# Altium schematic sheet S7CAS-PLEIADES-CARTE-MERE_P01_ALIM.SchDoc
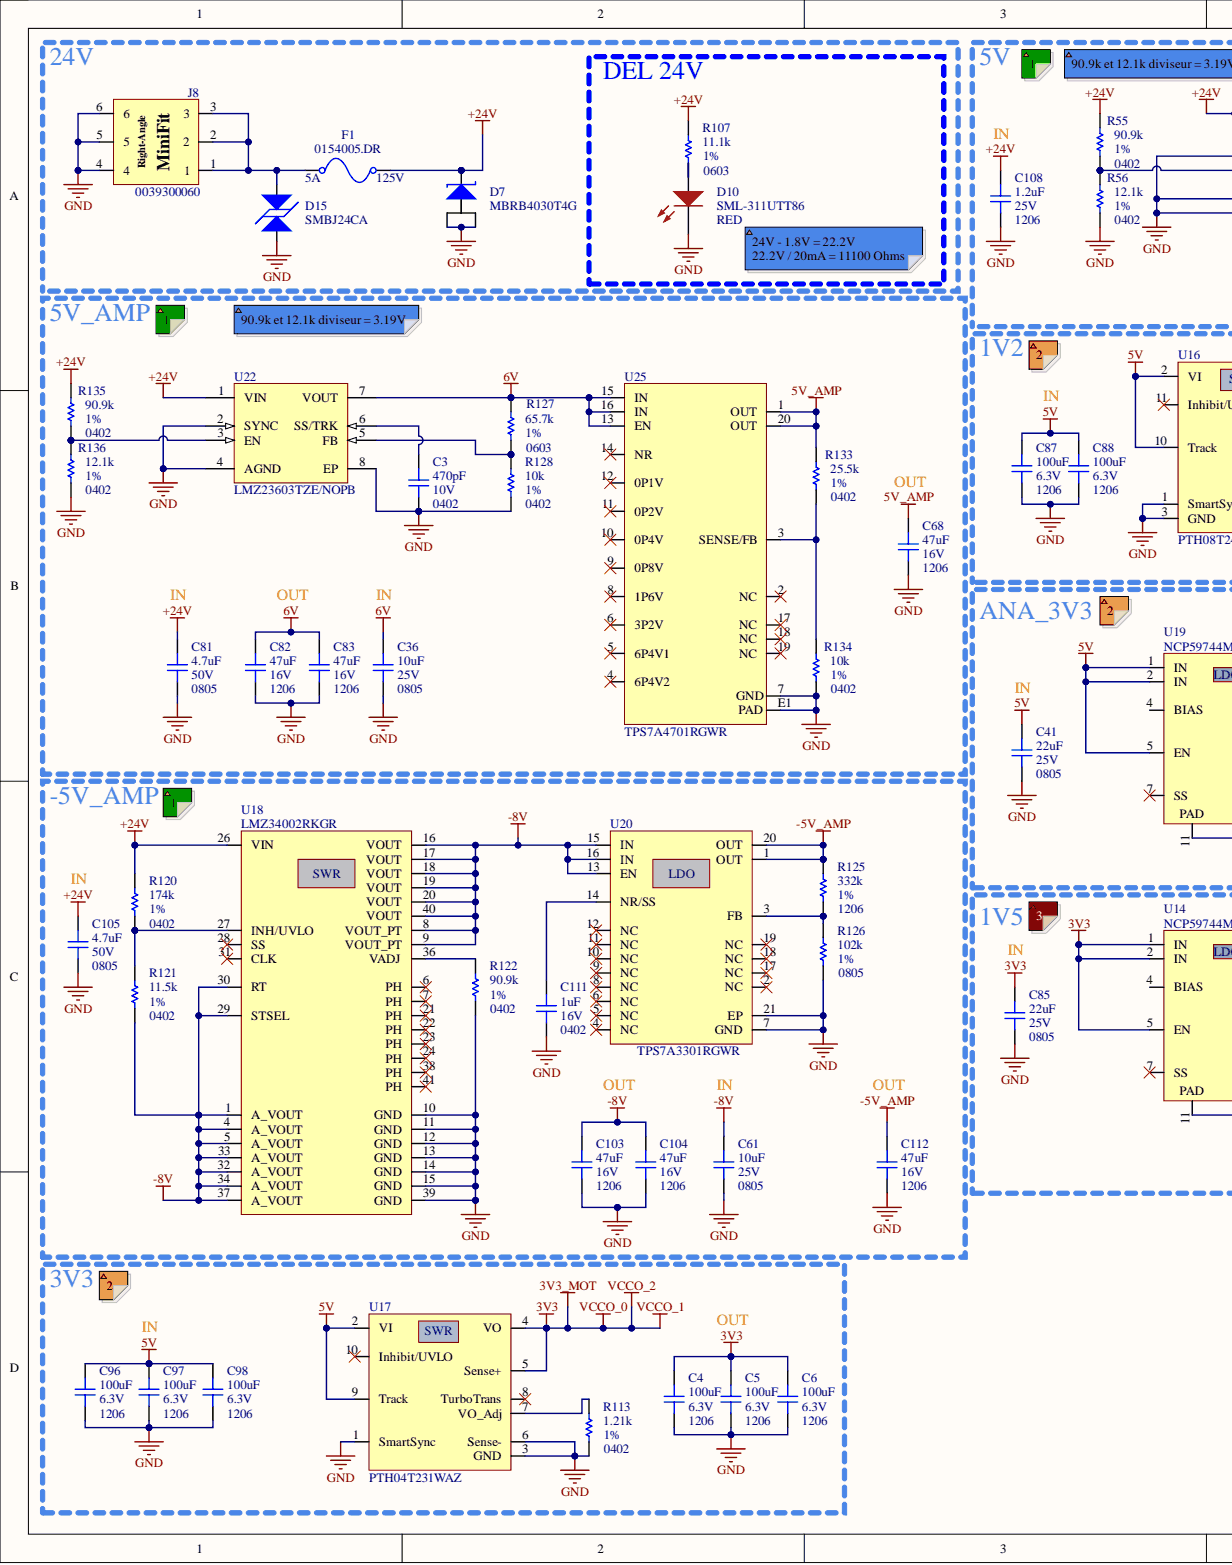
[diagram p0: S7CAS-PLEIADES-CARTE-MERE_P01_ALIM.SchDoc, left]
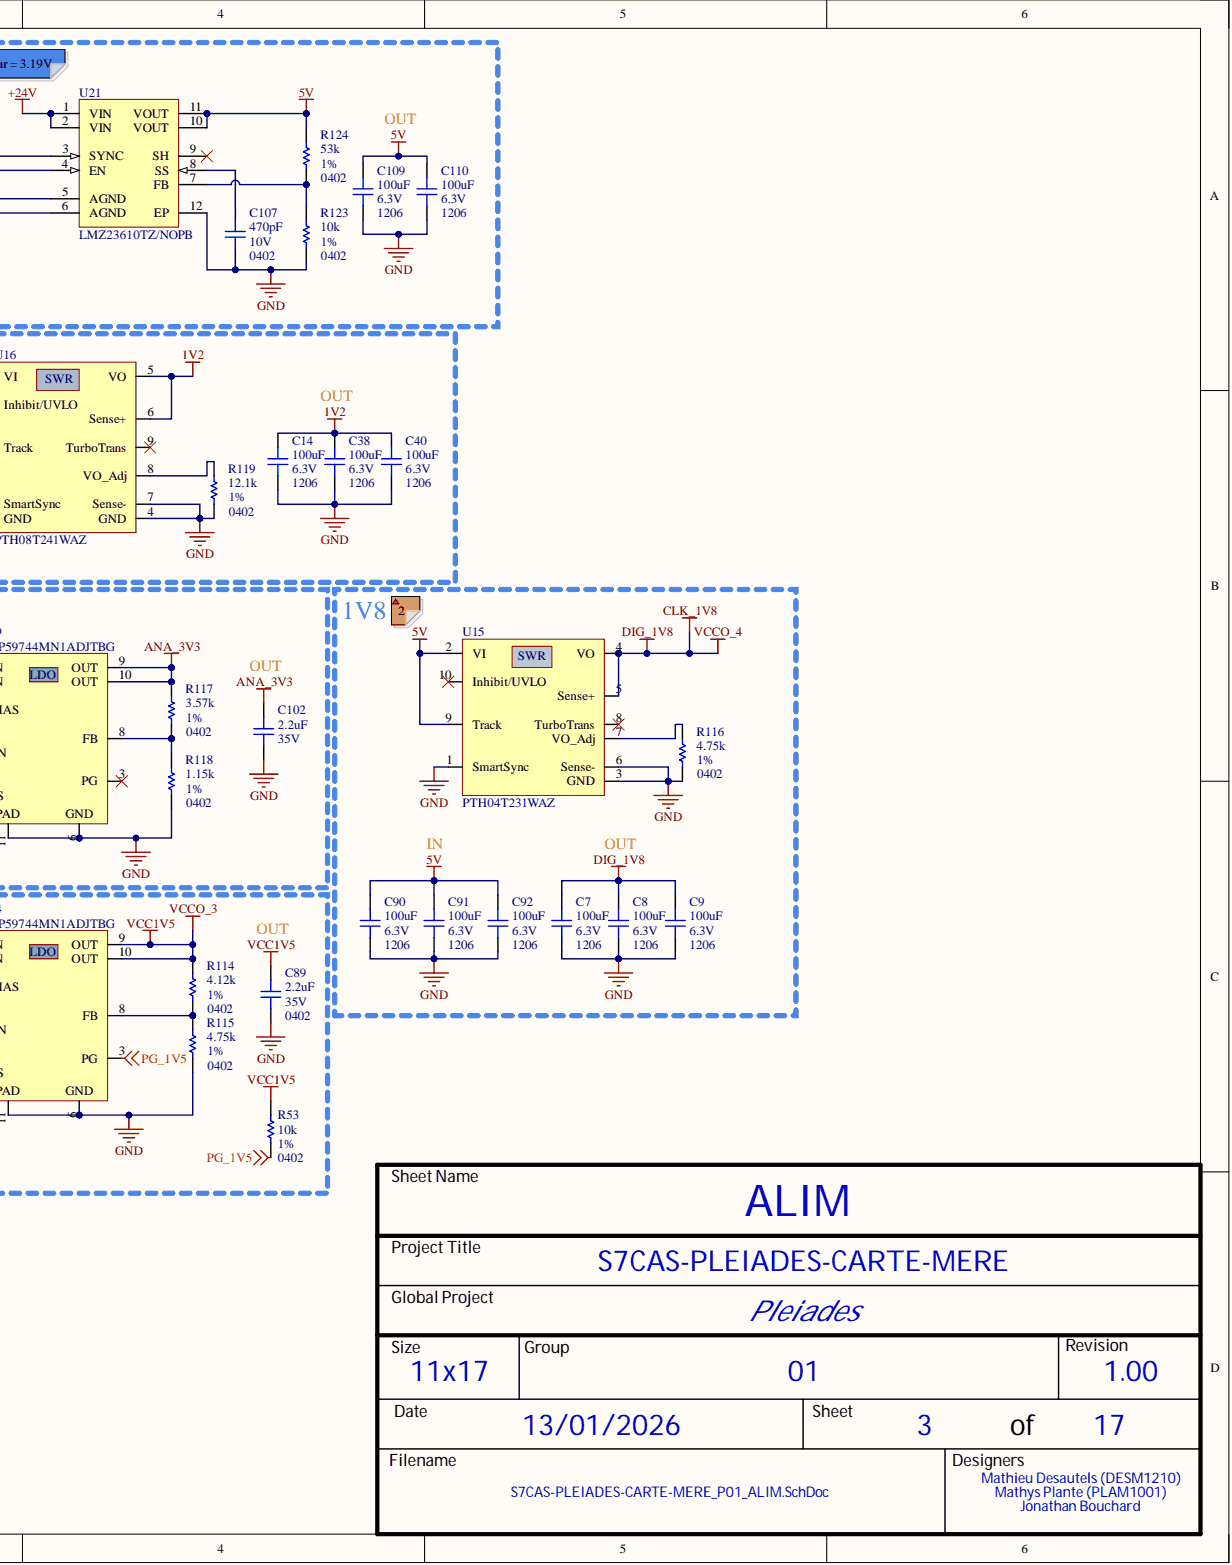
[diagram p1: S7CAS-PLEIADES-CARTE-MERE_P01_ALIM.SchDoc, right]

## Parts
C3  value 470pF  Package: 0402  Voltage: 10V  [CAP_0402]  (hidden comment: CAP - 0402)
C4  value 100uF  Package: 1206  Voltage: 6.3V  [CAP_1206]  (hidden comment: CAP - 1206)
C5  value 100uF  Package: 1206  Voltage: 6.3V  [CAP_1206]  (hidden comment: CAP - 1206)
C6  value 100uF  Package: 1206  Voltage: 6.3V  [CAP_1206]  (hidden comment: CAP - 1206)
C7  value 100uF  Package: 1206  Voltage: 6.3V  [CAP_1206]  (hidden comment: CAP - 1206)
C8  value 100uF  Package: 1206  Voltage: 6.3V  [CAP_1206]  (hidden comment: CAP - 1206)
C9  value 100uF  Package: 1206  Voltage: 6.3V  [CAP_1206]  (hidden comment: CAP - 1206)
C14  value 100uF  Package: 1206  Voltage: 6.3V  [CAP_1206]  (hidden comment: CAP - 1206)
C36  value 10uF  Package: 0805  Voltage: 25V  [CAP_0805]  (hidden comment: CAP - 0805)
C38  value 100uF  Package: 1206  Voltage: 6.3V  [CAP_1206]  (hidden comment: CAP - 1206)
C40  value 100uF  Package: 1206  Voltage: 6.3V  [CAP_1206]  (hidden comment: CAP - 1206)
C41  value 22uF  Package: 0805  Voltage: 25V  [CAP_0805]  (hidden comment: CAP - 0805)
C61  value 10uF  Package: 0805  Voltage: 25V  [CAP_0805]  (hidden comment: CAP - 0805)
C68  value 47uF  Package: 1206  Voltage: 16V  [CAP_1206]  (hidden comment: CAP - 1206)
C81  value 4.7uF  Package: 0805  Voltage: 50V  [CAP_0805]  (hidden comment: CAP - 0805)
C82  value 47uF  Package: 1206  Voltage: 16V  [CAP_1206]  (hidden comment: CAP - 1206)
C83  value 47uF  Package: 1206  Voltage: 16V  [CAP_1206]  (hidden comment: CAP - 1206)
C85  value 22uF  Package: 0805  Voltage: 25V  [CAP_0805]  (hidden comment: CAP - 0805)
C87  value 100uF  Package: 1206  Voltage: 6.3V  [CAP_1206]  (hidden comment: CAP - 1206)
C88  value 100uF  Package: 1206  Voltage: 6.3V  [CAP_1206]  (hidden comment: CAP - 1206)
C89  value 2.2uF  Package: 0402  Voltage: 35V  [CAP_0402]  (hidden comment: CAP - 0402)
C90  value 100uF  Package: 1206  Voltage: 6.3V  [CAP_1206]  (hidden comment: CAP - 1206)
C91  value 100uF  Package: 1206  Voltage: 6.3V  [CAP_1206]  (hidden comment: CAP - 1206)
C92  value 100uF  Package: 1206  Voltage: 6.3V  [CAP_1206]  (hidden comment: CAP - 1206)
C96  value 100uF  Package: 1206  Voltage: 6.3V  [CAP_1206]  (hidden comment: CAP - 1206)
C97  value 100uF  Package: 1206  Voltage: 6.3V  [CAP_1206]  (hidden comment: CAP - 1206)
C98  value 100uF  Package: 1206  Voltage: 6.3V  [CAP_1206]  (hidden comment: CAP - 1206)
C102  value 2.2uF  Voltage: 35V  [CAP_0402]  (hidden comment: CAP - 0402)
C103  value 47uF  Package: 1206  Voltage: 16V  [CAP_1206]  (hidden comment: CAP - 1206)
C104  value 47uF  Package: 1206  Voltage: 16V  [CAP_1206]  (hidden comment: CAP - 1206)
C105  value 4.7uF  Package: 0805  Voltage: 50V  [CAP_0805]  (hidden comment: CAP - 0805)
C107  value 470pF  Package: 0402  Voltage: 10V  [CAP_0402]  (hidden comment: CAP - 0402)
C108  value 1.2uF  Package: 1206  Voltage: 25V  [CAP_1206]  (hidden comment: CAP - 1206)
C109  value 100uF  Package: 1206  Voltage: 6.3V  [CAP_1206]  (hidden comment: CAP - 1206)
C110  value 100uF  Package: 1206  Voltage: 6.3V  [CAP_1206]  (hidden comment: CAP - 1206)
C111  value 1uF  Package: 0402  Voltage: 16V  [CAP_0402]  (hidden comment: CAP - 0402)
C112  value 47uF  Package: 1206  Voltage: 16V  [CAP_1206]  (hidden comment: CAP - 1206)
D7  MBRB4030T4G  [DIO_SCHOTTKY_MBRB4030T4G]
D10  SML-311UTT86  value RED  Package: 0603  [LED_0603]
D15  SMBJ24CA  [TVS_PWR_SMBJ36CA]
F1  0154005.DR  Current Rating: 5A  Voltage Rating: 125V  [FUS_0154XXX.DR]
J8  0039300060  [CON_MINIFIT_0039300060]
R53  value 10k  Package: 0402  Precision: 1%  [RES_0402]  (hidden comment: RES - 0402)
R55  value 90.9k  Precision: 1%  [RES_0402]  (hidden comment: RES - 0402)
R56  value 12.1k  Package: 0402  Precision: 1%  [RES_0402]  (hidden comment: RES - 0402)
R107  value 11.1k  [RES_0603]  (hidden comment: RES - 0603)
R113  value 1.21k  Package: 0402  Precision: 1%  [RES_0402]  (hidden comment: RES - 0402)
R114  value 4.12k  Precision: 1%  [RES_0402]  (hidden comment: RES - 0402)
R115  value 4.75k  Package: 0402  Precision: 1%  [RES_0402]  (hidden comment: RES - 0402)
R116  value 4.75k  Package: 0402  Precision: 1%  [RES_0402]  (hidden comment: RES - 0402)
R117  value 3.57k  Package: 0402  Precision: 1%  [RES_0402]  (hidden comment: RES - 0402)
R118  value 1.15k  Package: 0402  Precision: 1%  [RES_0402]  (hidden comment: RES - 0402)
R119  value 12.1k  Package: 0402  Precision: 1%  [RES_0402]  (hidden comment: RES - 0402)
R120  value 174k  Package: 0402  Precision: 1%  [RES_0402]  (hidden comment: RES - 0402)
R121  value 11.5k  Package: 0402  Precision: 1%  [RES_0402]  (hidden comment: RES - 0402)
R122  value 90.9k  Package: 0402  Precision: 1%  [RES_0402]  (hidden comment: RES - 0402)
R123  value 10k  Package: 0402  Precision: 1%  [RES_0402]  (hidden comment: RES - 0402)
R124  value 53k  Package: 0402  Precision: 1%  [RES_0402]  (hidden comment: RES - 0402)
R125  value 332k  Package: 1206  Precision: 1%  [RES_1206]  (hidden comment: RES - 1206)
R126  value 102k  Package: 0805  Precision: 1%  [RES_0805]  (hidden comment: RES - 0805)
R127  value 65.7k  Precision: 1%  [RES_0603]  (hidden comment: RES - 0603)
R128  value 10k  Package: 0402  Precision: 1%  [RES_0402]  (hidden comment: RES - 0402)
R133  value 25.5k  Package: 0402  Precision: 1%  [RES_0402]  (hidden comment: RES - 0402)
R134  value 10k  Package: 0402  Precision: 1%  [RES_0402]  (hidden comment: RES - 0402)
R135  value 90.9k  Precision: 1%  [RES_0402]  (hidden comment: RES - 0402)
R136  value 12.1k  Package: 0402  Precision: 1%  [RES_0402]  (hidden comment: RES - 0402)
U14  NCP59744MN1ADJTBG  [REG_LDO_NCP59744MN1ADJTBG]
U15  PTH04T231WAZ  [REG_SWR_PTH04T231WAZ]
U16  PTH08T241WAZ  [REG_SWR_PTH08T241WAZ]
U17  PTH04T231WAZ  [REG_SWR_PTH04T231WAZ]
U18  LMZ34002RKGR  [REG_SWR_LMZ34002RKGR]
U19  NCP59744MN1ADJTBG  [REG_LDO_NCP59744MN1ADJTBG]
U20  TPS7A3301RGWR  [REG_LDO_TPS7A3301RGWR]
U21  LMZ23610TZ/NOPB  [NDY0011A_M]
U22  LMZ23603TZE/NOPB  [NDW0007A_V]
U25  TPS7A4701RGWR  [REG_TPS7A4701RGWR]

## Nets
1V2: C14.2 C38.2 C40.2 U16.5(VO) U16.6(Sense+)
3V3: C4.2 C5.2 C6.2 C85.2 U14.1(IN) U14.2(IN) U14.5(EN) U17.4(VO) U17.5(Sense+)
5V: C41.2 C87.2 C88.2 C90.2 C91.2 C92.2 C96.2 C97.2 C98.2 C109.2 C110.2 R124.2 U15.2(VI) U15.9(Track) U16.2(VI) U16.10(Track) U17.2(VI) U17.9(Track) U19.1(IN) U19.2(IN) U19.5(EN) U21.10(VOUT) U21.11(VOUT)
5V_AMP: C68.2 R133.2 U25.1(OUT) U25.20(OUT)
6V: C36.2 C82.2 C83.2 R127.2 U22.7(VOUT) U25.13(EN) U25.15(IN) U25.16(IN)
+24V: C81.2 C105.2 C108.2 D7.4 F1.2 R55.2 R107.2 R120.2 R135.2 U18.26(VIN) U21.1(VIN) U21.2(VIN) U22.1(VIN)
-5V_AMP: C112.2 R125.2 U20.1(OUT) U20.20(OUT)
-8V: C61.2 C103.2 C104.2 R121.1 U18.1(A_VOUT) U18.4(A_VOUT) U18.5(A_VOUT) U18.8(VOUT_PT) U18.9(VOUT_PT) U18.16(VOUT) U18.17(VOUT) U18.18(VOUT) U18.19(VOUT) U18.20(VOUT) U18.29(STSEL) U18.30(RT) U18.32(A_VOUT) U18.33(A_VOUT) U18.34(A_VOUT) U18.37(A_VOUT) U18.40(VOUT) U20.13(EN) U20.15(IN) U20.16(IN)
ANA_3V3: C102.2 R117.2 U19.9(OUT) U19.10(OUT)
CLK_1V8: C7.2 C8.2 C9.2 U15.4(VO) U15.5(Sense+)
GND: C3.1 C4.1 C5.1 C6.1 C7.1 C8.1 C9.1 C14.1 C36.1 C38.1 C40.1 C41.1 C61.1 C68.1 C81.1 C82.1 C83.1 C85.1 C87.1 C88.1 C89.1 C90.1 C91.1 C92.1 C96.1 C97.1 C98.1 C102.1 C103.1 C104.1 C105.1 C107.1 C108.1 C109.1 C110.1 C111.1 C112.1 D7.1 D7.3 D10.K D15.2 J8.4 J8.5 J8.6 R56.1 R113.1 R115.1 R116.1 R118.1 R119.1 R122.1 R123.1 R126.1 R128.1 R134.1 R136.1 U14.6 U14.11 U15.1(SmartSync) U15.3(GND) U15.6(Sense-) U16.1(SmartSync) U16.3(GND) U16.4(GND) U16.7(Sense-) U17.1(SmartSync) U17.3(GND) U17.6(Sense-) U18.10(GND) U18.11(GND) U18.12(GND) U18.13(GND) U18.14(GND) U18.15(GND) U18.39(GND) U19.6 U19.11 U20.7(GND) U20.21(EP) U21.3(SYNC) U21.5(AGND) U21.6(AGND) U21.12(EP) U22.2(SYNC) U22.4(AGND) U22.8(EP) U25.7(GND) U25.E1(PAD)
PG_1V5: R53.1 U14.3(PG)
VCC1V5: C89.2 R53.2 R114.2 U14.9(OUT) U14.10(OUT)
NetC3_2: C3.2 U22.6(SS/TRK)
NetC107_2: C107.2 U21.8(SS)
NetC111_2: C111.2 U20.14(NR/SS)
NetD10_A: D10.A R107.1
NetD15_1: D15.1 F1.1 J8.1 J8.2 J8.3
NetR55_1: R55.1 R56.2 U21.4(EN)
NetR113_2: R113.2 U17.7(VO_Adj)
NetR114_1: R114.1 R115.2 U14.8(FB)
NetR116_2: R116.2 U15.7(VO_Adj)
NetR117_1: R117.1 R118.2 U19.8(FB)
NetR119_2: R119.2 U16.8(VO_Adj)
NetR120_1: R120.1 R121.2 U18.27(INH/UVLO)
NetR122_2: R122.2 U18.36(VADJ)
NetR123_2: R123.2 R124.1 U21.7(FB)
NetR125_1: R125.1 R126.2 U20.3(FB)
NetR127_1: R127.1 R128.2 U22.5(FB)
NetR133_1: R133.1 R134.2 U25.3(SENSE/FB)
NetR135_1: R135.1 R136.2 U22.3(EN)

Not connected: U14 4(BIAS) 7(SS); U15 8(TurboTrans) 10(Inhibit/UVLO); U16 9(TurboTrans) 11(Inhibit/UVLO); U17 8(TurboTrans) 10(Inhibit/UVLO); U18 6(PH) 7(PH) 21(PH) 22(PH) 23(PH) 24(PH) 28(SS) 31(CLK) 38(PH) 41(PH); U19 3(PG) 4(BIAS) 7(SS); U20 2(NC) 4(NC) 5(NC) 6(NC) 8(NC) 9(NC) 10(NC) 11(NC) 12(NC) 17(NC) 18(NC) 19(NC); U21 9(SH); U25 2(NC) 4(6P4V2) 5(6P4V1) 6(3P2V) 8(1P6V) 9(0P8V) 10(0P4V) 11(0P2V) 12(0P1V) 14(NR) 17(NC) 18(NC) 19(NC)

## Library data of the parts
C3 C107 = CAP - 0402: Mfg Part Number: C0402C471K8RACAUTO; Precision: 10%; Component Kind: Standard; Description: CAP - 0402; Library Name: s7cas_lib2023.intlib; CreatedBy: Jonathan Bouchard
C4-C9 C14 C38 C40 C87 C88 C90-C92 C96-C98 C109 C110 = CAP - 1206: 100 µF ±20% 6.3V Ceramic Capacitor X6T 1206 (3216 Metric); Mfg Part Number: GRM31CD80J107MEA8K; Mfg: Murata Electronics; Component Kind: Standard; Library Name: s7cas_lib2023.intlib; Precision: 20%; CreatedBy: Jonathan Bouchard
C36 C61 = CAP - 0805: 10 µF ±10% 25V Ceramic Capacitor X5R 0805 (2012 Metric); Mfg Part Number: CL21A106KAYNNNE; Mfg: Samsung Electro-Mechanics; Component Kind: Standard; Library Name: s7cas_lib2023.intlib; Part Number #1: CL21A106KAYNNNE; Precision: 10%; CreatedBy: Jonathan Bouchard
C41 C85 = CAP - 0805: 22 µF ±20% 25V Ceramic Capacitor X5R 0805 (2012 Metric); Mfg Part Number: CL21A226MAYNNNE; Mfg: Samsung Electro-Mechanics; Component Kind: Standard; Library Name: s7cas_lib2023.intlib; Precision: 20%; CreatedBy: Jonathan Bouchard
C68 C82 C83 C103 C104 C112 = CAP - 1206: 47 µF ±20% 16V Ceramic Capacitor X5R 1206 (3216 Metric); Mfg Part Number: EMK316BBJ476ML-T; Mfg: Taiyo Yuden; Component Kind: Standard; Library Name: s7cas_lib2023.intlib; Precision: 20%; CreatedBy: Jonathan Bouchard
C81 C105 = CAP - 0805: 4.7 µF ±10% 50V Ceramic Capacitor X5R 0805 (2012 Metric); Mfg Part Number: GRT21BR61H475KE13L; Mfg: Murata Electronics; Component Kind: Standard; Library Name: s7cas_lib2023.intlib; Precision: 10%; CreatedBy: Jonathan Bouchard
C89 C102 = CAP - 0402: 2.2 µF ±10% 35V Ceramic Capacitor X5R 0402 (1005 Metric); Mfg Part Number: GRM155R6YA225KE11D; Part Number #1: CL05A225KP5NSNC; Mfg: Murata Electronics; Component Kind: Standard; Library Name: s7cas_lib2023.intlib; Precision: 10%; CreatedBy: Jonathan Bouchard
  C102: Package: 0402
C108 = CAP - 1206: 1.2 µF ±10% 25V Ceramic Capacitor X7R 1206 (3216 Metric); Mfg Part Number: C1206C125K3RACTU; Mfg: KEMET; Component Kind: Standard; Library Name: s7cas_lib2023.intlib; Precision: 10%; CreatedBy: Jonathan Bouchard
C111 = CAP - 0402: 1 µF ±10% 16V Ceramic Capacitor X5R 0402 (1005 Metric); Mfg Part Number: CL05A105KO5NNNC; Mfg: Samsung Electro-Mechanics; Component Kind: Standard; Library Name: s7cas_lib2023.intlib; Precision: 10%; CreatedBy: Jonathan Bouchard
D7 = DIO_SCHOTTKY_MBRB4030T4G: DIO - 30V MAX 40 A - TO-263-3; Manufacturer #1: ON Semiconductor; Cryo: UNKNOWN; Current Rating: 40A; GRAMS Lib Spec: 2.8; Magnetic Material: NO; Polarized: Yes; Value: Vf = 550mV @ 40A; Component Kind: Standard; HelpURL: http://www.onsemi.com/pub/Collateral/MBRB4030-D.PDF; Library Name: s7cas_lib2023.intlib; Mfg Part Number: MBRB4030T4G; Package: D2PAK; Part Number #1: MBRB4030T4G; Supplier 1: Digi-Key; Supplier Part Number 1: MBRB4030T4GOSCT-ND; Temperature: -65°C ~ 175°C; Voltage Rating: 30V; CreatedBy/Date: Arnaud Samson - 06/07/18; VerifiedBy: Jonathan Bouchard 2018-07-12
D10 = LED_SML-311UTT86: LED - 620nM RED 2mA - 0603; Mfg: ROHM Semiconductor; Power: 44mW; Voltage: 1.8V; Component Kind: Standard; Current: 2mA; HelpURL: http://media.digikey.com/pdf/Data%20Sheets/Rohm%20PDFs/SML-311%20Series.pdf; Library Name: s7cas_lib2023.intlib; Mfg Part Number: SML-311UTT86; Supplier 1: Digikey; Supplier Part Number 1: 511-1304-1-ND; Temperature: -30°C to 85°C; CreatedBy: Jonathan Bouchard
D15 = TVS_PWR_SMBJ24CA: TVS - 24V 26.7V 600W - DO214AA; Manufacturer #1: Littelfuse Inc.; Cryo: UNKNOWN; Current Rating: 15.5A; GRAMS Lib Spec: 2.8; Polarized: NO; Value: Vbr = 26.7V -  Clamp = 38.9V; Voltage Rating: 24V Standoff; Assembly Type: SMD; Component Kind: Standard; HelpURL: http://www.littelfuse.com/~/media/electronics/datasheets/tvs_diodes/littelfuse_tvs_diode_smbj_datasheet.pdf.pdf; Library Name: s7cas_lib2023.intlib; Magnetic Material: UNKNOWN; Package: DO214AA; Part Number #1: SMBJ24CA; Power Max: 600W; Supplier 1: Digi-Key; Supplier Part Number 1: SMBJ24CALFCT-ND; Temperature: -65°C ~ 150°C (TJ); CreatedBy/Date: Arnaud Samson - 06/07/18; VerifiedBy: Jonathan Bouchard - 2018-07-13
F1 = FUS_0154005.DR: FUS - 5 A 125 VAC/VDC - 2-SMD; Manufacturer #1: Littelfuse Inc.; Cryo: UNKNOWN; GRAMS Lib Spec: 2.8; Polarized: NO; Value: 5A 125V AC/DC FAST; Component Kind: Standard; HelpURL: http://www.littelfuse.com/~/media/electronics/datasheets/fuses/littelfuse_fuse_154_154t_154l_154tl_datasheet.pdf.pdf; Library Name: s7cas_lib2023.intlib; Magnetic Material: UNKNOWN; Mfg Part Number: 0154005.DR; Package: 2-SMD; Part Number #1: 0154005.DR; Supplier 1: Digi-Key; Supplier Part Number 1: F1228CT-ND; Temperature: -55°C ~ 125°C; CreatedBy/Date: Arnaud Samson - 06/07/18; VerifiedBy: Jonathan Bouchard 2018-07-16
J8 = CON_MINIFIT_0039300060: CON - Mini-Fit Through Hole, Right Angle 6 position; Manufacturer #1: Molex; Cryo: UNKNOWN; Current Rating: 8A; GRAMS Lib Spec: 2.8; Polarized: Yes; Voltage Rating: 600V; Component Kind: Standard; HelpURL: https://www.molex.com/pdm_docs/sd/039300060_sd.pdf; Library Name: s7cas_lib2023.intlib; Magnetic Material: Unknown; Mfg Part Number: 0039300060; Package: TH; Part Number #1: 0039300060; Supplier 1: Digi-Key; Supplier Part Number 1: WM21353-ND; Temperature: - 40°C to + 80°C; CreatedBy/Date: Joel Lemay 2018-06-21; VerifiedBy: Caroline Paulin - 10 sept 2018
R53 = RES - 0402: 10 kOhms ±1% 0.063W, 1/16W Chip Resistor 0402 (1005 Metric) Automotive AEC-Q200 Thick Film; Mfg Part Number: RMCF0402FT10K0; Voltage: 75V; Component Kind: Standard; Library Name: s7cas_lib2023.intlib; Part Number #1: RMCF0402FT10K0; Power: 1/16W; CreatedBy: Jonathan Bouchard
R55 R122 R135 = RES - 0402: 90.9 kOhms ±1% 0.063W, 1/16W Chip Resistor 0402 (1005 Metric) Moisture Resistant Thick Film; Mfg Part Number: RC0402FR-0790K9L; Mfg: YAGEO; Voltage: 50V; Component Kind: Standard; Library Name: s7cas_lib2023.intlib; Power: 1/16W; CreatedBy: Jonathan Bouchard
  R55 R135: Package: 0402
R56 R119 R136 = RES - 0402: 12.1 kOhms ±1% 0.063W, 1/16W Chip Resistor 0402 (1005 Metric) Moisture Resistant Thick Film; Mfg Part Number: RC0402FR-0712K1L; Mfg: YAGEO; Voltage: 50V; Component Kind: Standard; Library Name: s7cas_lib2023.intlib; Power: 1/16W; CreatedBy: Jonathan Bouchard
R107 = RES - 0603: 11.1 kOhms ±0.1% 0.167W, 1/6W Chip Resistor 0603 (1608 Metric) Automotive AEC-Q200 Thin Film; Mfg Part Number: RNCF0603BTE11K1; Mfg: Stackpole Electronics Inc; Precision: 1%; Component Kind: Standard; Library Name: s7cas_lib2023.intlib; Package: 0603; CreatedBy: Jonathan Bouchard
R113 = RES - 0402: 1.21 kOhms ±1% 0.063W, 1/16W Chip Resistor 0402 (1005 Metric) Automotive AEC-Q200 Thick Film; Mfg Part Number: RMCF0402FT1K21; Mfg: Stackpole Electronics Inc; Voltage: 50V; Component Kind: Standard; Library Name: s7cas_lib2023.intlib; Power: 1/16W; CreatedBy: Jonathan Bouchard
R114 = RES - 0402: 4.12 kOhms ±0.1% 0.063W, 1/16W Chip Resistor 0402 (1005 Metric) Automotive AEC-Q200 Thin Film; Mfg Part Number: ERA-2AEB4121X; Mfg: Panasonic Electronic Components; Voltage: 50V; Component Kind: Standard; Library Name: s7cas_lib2023.intlib; Package: 0402; Power: 1/16W; CreatedBy: Jonathan Bouchard
R115 R116 = RES - 0402: 4.75 kOhms ±0.1% 0.063W, 1/16W Chip Resistor 0402 (1005 Metric) Automotive AEC-Q200 Thin Film; Mfg Part Number: ERA-2AEB4751X; Mfg: Panasonic Electronic Components; Voltage: 50V; Component Kind: Standard; Library Name: s7cas_lib2023.intlib; Power: 1/16W; CreatedBy: Jonathan Bouchard
R117 = RES - 0402: 3.57 kOhms ±1% 0.063W, 1/16W Chip Resistor 0402 (1005 Metric) Moisture Resistant Thick Film; Mfg Part Number: RC0402FR-073K57L; Mfg: YAGEO; Voltage: 50V; Component Kind: Standard; Library Name: s7cas_lib2023.intlib; Power: 1/16W; CreatedBy: Jonathan Bouchard
R118 = RES - 0402: 1.15 kOhms ±1% 0.1W, 1/10W Chip Resistor 0603 (1608 Metric) Automotive AEC-Q200 Thick Film; Mfg Part Number: ERJ-3EKF1151V; Mfg: Panasonic Electronic Components; Power: 1/16W; Voltage: 50V; Component Kind: Standard; Library Name: s7cas_lib2023.intlib; CreatedBy: Jonathan Bouchard
R120 = RES - 0402: 174 kOhms ±1% 0.063W, 1/16W Chip Resistor 0402 (1005 Metric) Moisture Resistant Thick Film; Mfg Part Number: RC0402FR-07174KL; Mfg: YAGEO; Voltage: 50V; Component Kind: Standard; Library Name: s7cas_lib2023.intlib; Power: 1/16W; CreatedBy: Jonathan Bouchard
R121 = RES - 0402: 11.5 kOhms ±1% 0.063W, 1/16W Chip Resistor 0402 (1005 Metric) Moisture Resistant Thick Film; Mfg Part Number: RC0402FR-0711K5L; Mfg: YAGEO; Voltage: 50V; Component Kind: Standard; Library Name: s7cas_lib2023.intlib; Power: 1/16W; CreatedBy: Jonathan Bouchard
R123 R128 R134 = RES - 0402: 10 kOhms ±1% 0.063W, 1/16W Chip Resistor 0402 (1005 Metric) Moisture Resistant Thick Film; Mfg Part Number: RC0402FR-0710KL; Mfg: YAGEO; Voltage: 50V; Component Kind: Standard; Library Name: s7cas_lib2023.intlib; Power: 1/16W; CreatedBy: Jonathan Bouchard
R124 = RES - 0402: 53 kOhms ±0.1% 0.063W, 1/16W Chip Resistor 0402 (1005 Metric) Thin Film; Mfg Part Number: RT0402BRD0753KL; Mfg: YAGEO; Voltage: 50V; Component Kind: Standard; Library Name: s7cas_lib2023.intlib; Power: 1/16W; CreatedBy: Jonathan Bouchard
R125 = RES - 1206: 332 kOhms ±0.1% 0.25W, 1/4W Chip Resistor 1206 (3216 Metric) Automotive AEC-Q200 Thin Film; Mfg Part Number: ERA-8AEB3323V; Mfg: Panasonic Electronic Components; Component Kind: Standard; Library Name: s7cas_lib2023.intlib; CreatedBy: Jonathan Bouchard
R126 = RES - 0805: 102 kOhms ±0.05% 0.125W, 1/8W Chip Resistor 0805 (2012 Metric) Anti-Sulfur, Automotive AEC-Q200, Moisture Resistant Thin Film; Mfg Part Number: TNPU0805102KAYEA00; Mfg: Vishay Dale; Component Kind: Standard; Library Name: s7cas_lib2023.intlib; CreatedBy: Jonathan Bouchard
R127 = RES - 0603: 65.7 kOhms ±0.5% 0.1W, 1/10W Chip Resistor 0603 (1608 Metric) Thin Film; Mfg Part Number: RT0603DRE0765K7L; Mfg: YAGEO; Component Kind: Standard; Library Name: s7cas_lib2023.intlib; Package: 0603; CreatedBy: Jonathan Bouchard
R133 = RES - 0402: 25.5 kOhms ±0.1% 0.063W, 1/16W Chip Resistor 0402 (1005 Metric) Automotive AEC-Q200 Thin Film; Mfg Part Number: ERA-2AEB2552X; Mfg: Panasonic Electronic Components; Voltage: 50V; Component Kind: Standard; Library Name: s7cas_lib2023.intlib; Power: 1/16W; CreatedBy: Jonathan Bouchard
U14 U19 = REG_LDO_NCP59744MN1ADJTBG: REG - LINEAR POS ADJ 3A BIAS - 10-VFDFN; Manufacturer #1: ON Semiconductor; Cryo: UNKNOWN; GRAMS Lib Spec: 2.8; Polarized: Yes; Power Max: 10.8W; Value: 0.8V ~ 3.6V; Voltage Rating: (Vout+VDO) ~ 5.5V; Component Kind: Standard; Current Rating: 3A; HelpURL: https://www.onsemi.com/pub/Collateral/NCP59744-D.PDF; Library Name: s7cas_lib2023.intlib; Magnetic Material: Unknown; Manufacturer #2: -; Mfg Part Number: NCP59744MN1ADJTBG; Package: 10-VFDFN; Part Number #1: NCP59744MN1ADJTBG; Part Number #2: -; Supplier 1: Digi-Key; Supplier Part Number 1: NCP59744MN1ADJTBGOSCT-ND; Temperature: -40°C ~ 125°C (TJ); Tolerance: -; CreatedBy/Date: Raphael Bouchard / 2019-06-07; VerifiedBy: C.Paulin - 13/06/2019
U15 U17 = REG_SWR_PTH04T231WAZ: REG - MODULE PIP 6A 2.2V/5.5V - 10SMD; Manufacturer #1: Texas Instruments; Cryo: UNKNOWN; Current Rating: 6A (out); Polarized: Yes; Value: 0.69 - 3.6V (Out); Voltage rating: 2.2-5.5V (Input); Component Kind: Standard; HelpURL: http://www.ti.com/lit/ds/symlink/pth04t231w.pdf; Library Name: s7cas_lib2023.intlib; Magnetic Material: UNKNOWN; Mfg Part Number: PTH04T231WAZ; Package: SMD; Part Number #1: PTH04T231WAZ; Supplier 1: Digi-Key; Supplier Part Number 1: 296-21792-ND; Temperature: -40°C ~ 85°C; CreatedBy/Date: Olivier Bernard / 6/5/2018; VerifiedBy: Caroline Paulin / 10 juillet 2018
U16 = REG_SWR_PTH08T241WAZ: REG - MODULE PIP 10A 14VIN - 11-SMD; Manufacturer #1: Texas Instruments; Cryo: UNKNOWN; Current Rating: 10A (out); Polarized: Yes; Voltage rating: 4.5-14V (Input), 0.69 - 5.5V (Out); Component Kind: Standard; HelpURL: http://www.ti.com/lit/ds/symlink/pth08t241w.pdf; Library Name: s7cas_lib2023.intlib; Magnetic Material: UNKNOWN; Mfg Part Number: PTH08T241WAZ; Package: SMD; Part Number #1: PTH08T241WAZ; Supplier 1: Digi-Key; Supplier Part Number 1: 296-21339-ND; Temperature: -40°C ~ 85°C; CreatedBy/Date: Olivier Bernard / 6/5/2018; VerifiedBy: Caroline Paulin / 10 juillet 2018
U18 = REG_SWR_LMZ34002RKGR: REG - DC DC Converter -3V to -17V 2A - 41-B1QFN; Manufacturer #1: Texas Instruments; Cryo: UNKNOWN; GRAMS Lib Spec: 2.8c; Polarized: Yes; Power Max: 15W; Value: -3 ~ -17V; Voltage Rating: 4.5-40V; Component Kind: Standard; Current Rating: 2A; HelpURL: http://www.ti.com/lit/ds/symlink/lmz34002.pdf; Library Name: s7cas_lib2023.intlib; Magnetic Material: Unknown; Mfg Part Number: LMZ34002RKGR; Package: 41-B1QFN; Part Number #1: LMZ34002RKGR; Supplier 1: Digi-Key; Supplier Part Number 1: 296-44356-1-ND; Temperature: -40°C ~ 85°C; CreatedBy/Date: P.Marcoux  20-06-2019; VerifiedBy: C.Paulin - 22/01/2020
U20 = REG_LDO_TPS7A3301RGWR: REG - LDO Negative Adjustable 1 Output -1.18V-33V 1A -  20-VQFN (5x5); Manufacturer #1: Texas Instruments; Cryo: UNKNOWN; GRAMS Lib Spec: 2.8; Polarized: Yes; Value: -1.18V~-33V; Voltage Rating: -36V; Component Kind: Standard; Current Rating: 1A; HelpURL: http://www.ti.com/lit/ds/symlink/tps7a33.pdf; Library Name: s7cas_lib2023.intlib; Magnetic Material: Unknown; Mfg Part Number: TPS7A3301RGWR; Package: 20-VQFN; Part Number #1: TPS7A3301RGWR; Supplier 1: Digi-Key; Supplier Part Number 1: 296-39502-1-ND; Temperature: -40°C ~ 125°C; CreatedBy/Date: Keven Deslandes - 2018/10/11; VerifiedBy: Caroline Paulin - 11/12/2018
U21 = CMP-0069-02067-2: 10A SIMPLE SWITCHER® Power Module with 36V Maximum Input Voltage and Current Sharing, 11 pin TO-PMOD, 32 Rail; Manufacturer: Texas Instruments; PackageTopMarking: NSUZXYTTE#*LMZ23610; PowerWise: N; Component Kind: Standard; Library Name: Altium Content Vault; PackageDescription: TO-PMOD, 15 x 15 x 5.9 mm Body, 11 Lead, 1.27 mm Pitch, Surface Mount; PackageReference: NDY0011A; PinCount: 11; RoHS: Y
U22 = CMP-0069-02076-2: 3A SIMPLE SWITCHER® Power Module with 36V Maximum Input Voltage, 7 pin TO-PMOD, 250 Reel; Manufacturer: Texas Instruments; PackageTopMarking: NSUZXYTTE#*LMZ23603; PowerWise: N; Component Kind: Standard; ComponentLink1Description: Datasheet URL; ComponentLink1URL: http://www.ti.com/general/docs/lit/getliterature.tsp?genericPartNumber=LMZ23603&fileType=pdf; CompType: Switcher; Library Name: Altium Content Vault; PackageDescription: TO-PMOD-7, 10.16 x 9.85 x 4.57 mm Body, 7 Lead, 1.27mm Pitch, Surface Mount; PackageReference: NDW0007A; PinCount: 7; RoHS: Y
U25 = REG_LDO_TPS7A4701RGWR: REG - LINEAR POS ADJ 1A - 20VQFN; Manufacturer #1: Texas Instruments; Cryo: UNKNOWN; GRAMS Lib Spec: 2.8; Polarized: Yes; Value: 1.4 à 34V; Voltage rating: 35V max; Assembly Type: SMD; Component Kind: Standard; Current Rating: 1A; HelpURL: http://www.ti.com/lit/ds/symlink/tps7a47.pdf; Library Name: s7cas_lib2023.intlib; Magnetic Material: Unknown; Manufacturer #2: TBD; Package: 20-VQFN Exposed Pad; Part Number #1: TPS7A4701RGWR; Part Number #2: TBD; Supplier 1: Digi-Key; Supplier Part Number 1: 296-37224-1-ND; Temperature: -40°C ~ 125°C; Tolerance: -; CreatedBy/Date: L-P Gauthier / 2018-05-29; VerifiedBy: Caroline Paulin - 28 sept 2018
